# Altium schematic sheet Level_Shifter.SchDoc(Level_Shifter)
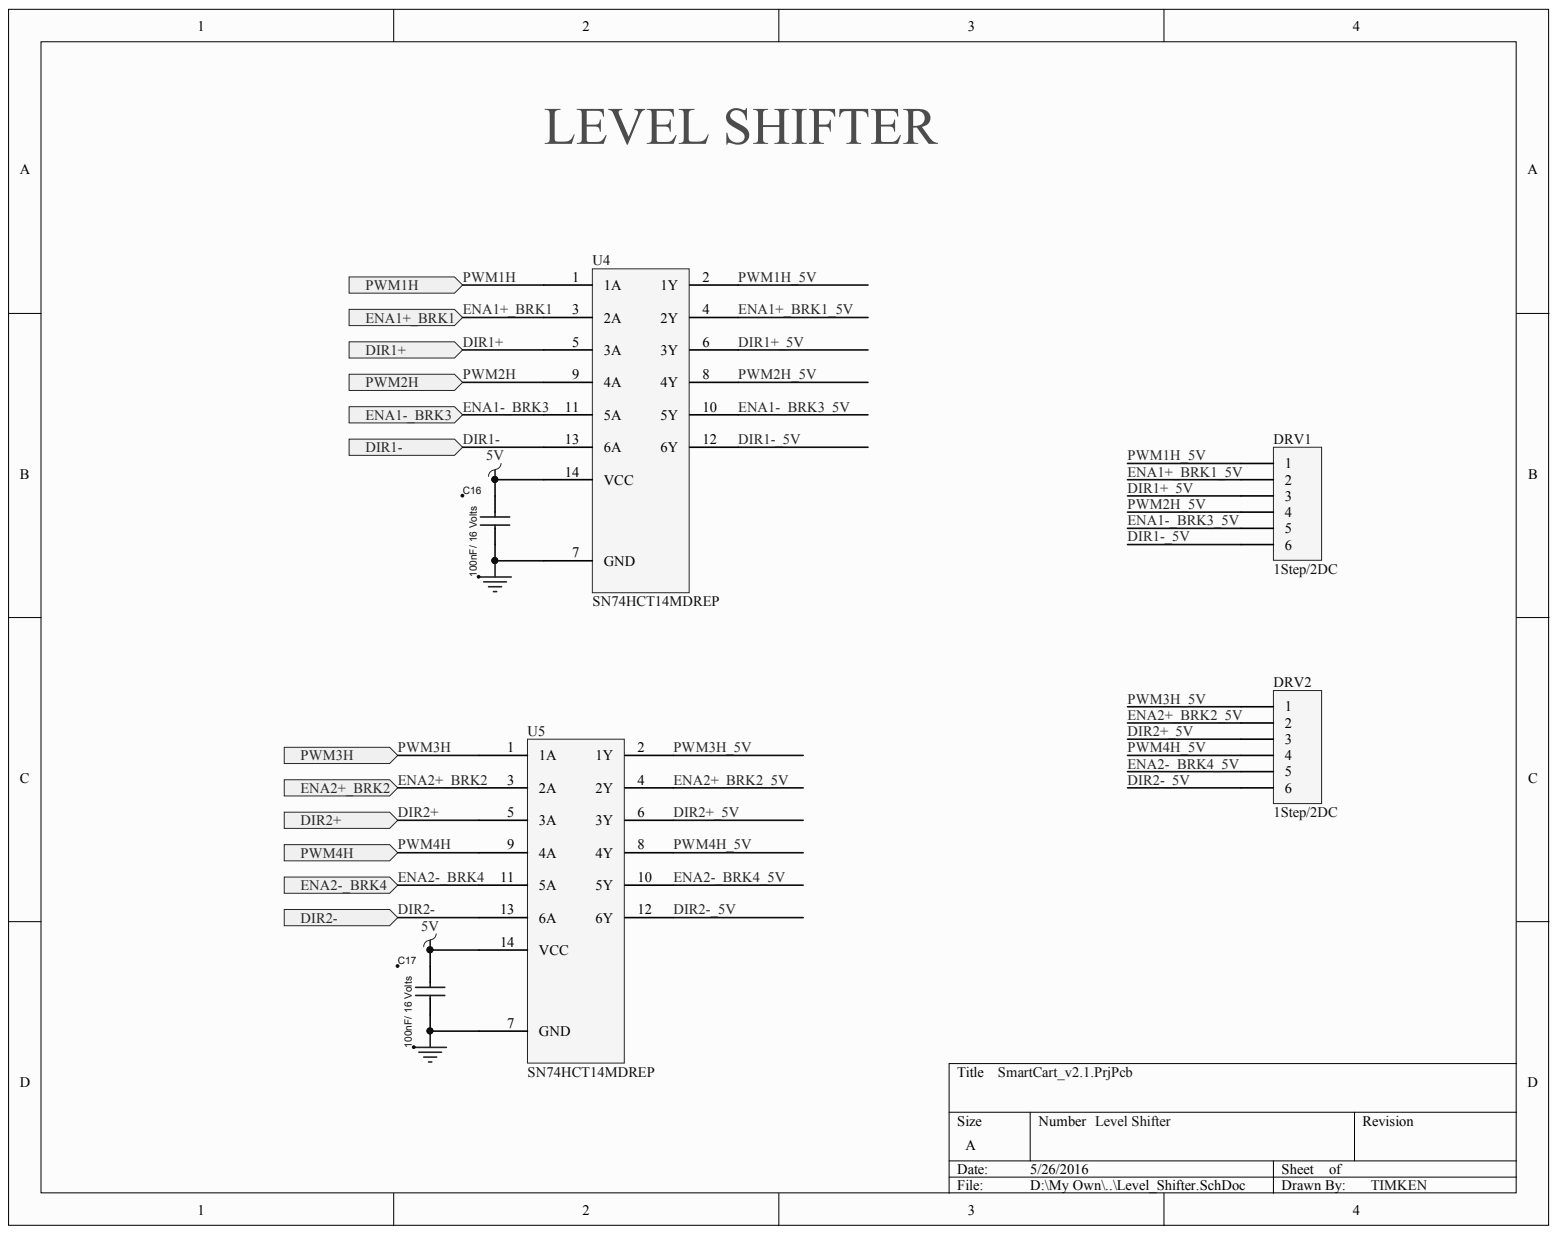
[diagram p0: Level_Shifter.SchDoc]

## Parts
C16  100nF/ 16 Volts  [C0805]
C17  100nF/ 16 Volts  [C0805]
DRV1  1Step/2DC  [1x6_single]
DRV2  1Step/2DC  [1x6_single]
U4  SN74HCT14MDREP  [SN74HCT14MDREP]
U5  SN74HCT14MDREP  [SN74HCT14MDREP]

## Ports
DIR1+, DIR1-, DIR2+, DIR2-, ENA1+_BRK1, ENA1-_BRK3, ENA2+_BRK2, ENA2-_BRK4, PWM1H, PWM2H, PWM3H, PWM4H

## Nets
5V: C16.2 C17.2 U4.14(VCC) U5.14(VCC)
GND_POWER: C16.1 C17.1 U4.7(GND) U5.7(GND)
DIR1+: U4.5(3A) ; port DIR1+
DIR1+_5V: DRV1.3 U4.6(3Y)
DIR1-: U4.13(6A) ; port DIR1-
DIR1-_5V: DRV1.6 U4.12(6Y)
DIR2+: U5.5(3A) ; port DIR2+
DIR2+_5V: DRV2.3 U5.6(3Y)
DIR2-: U5.13(6A) ; port DIR2-
DIR2-_5V: DRV2.6 U5.12(6Y)
ENA1+_BRK1: U4.3(2A) ; port ENA1+_BRK1
ENA1+_BRK1_5V: DRV1.2 U4.4(2Y)
ENA1-_BRK3: U4.11(5A) ; port ENA1-_BRK3
ENA1-_BRK3_5V: DRV1.5 U4.10(5Y)
ENA2+_BRK2: U5.3(2A) ; port ENA2+_BRK2
ENA2+_BRK2_5V: DRV2.2 U5.4(2Y)
ENA2-_BRK4: U5.11(5A) ; port ENA2-_BRK4
ENA2-_BRK4_5V: DRV2.5 U5.10(5Y)
PWM1H: U4.1(1A) ; port PWM1H
PWM1H_5V: DRV1.1 U4.2(1Y)
PWM2H: U4.9(4A) ; port PWM2H
PWM2H_5V: DRV1.4 U4.8(4Y)
PWM3H: U5.1(1A) ; port PWM3H
PWM3H_5V: DRV2.1 U5.2(1Y)
PWM4H: U5.9(4A) ; port PWM4H
PWM4H_5V: DRV2.4 U5.8(4Y)

## Library data of the parts
C16 C17 = CAP NP: Descr: CAPACITOR, 0805, 100NF, 16V, X7R; M P No: CC0805KRX7R7BB104; Vendor: Farnell; V P No: 644160RL; Component Kind: Standard; Library Name: combined_cart_schematic_lib.SchLib
DRV1 DRV2 = Header 6: Header, 6-Pin; Component Kind: Standard; LatestRevisionDate: 17-Jul-2002; LatestRevisionNote: Re-released for DXP Platform.; Library Name: combined_cart_schematic_lib.SchLib; Publisher: Altium Limited
U4 U5 = 74HC153D: Component Kind: Standard; Library Name: combined_cart_schematic_lib.SchLib
